# Altium schematic sheet Microcontroller.SchDoc
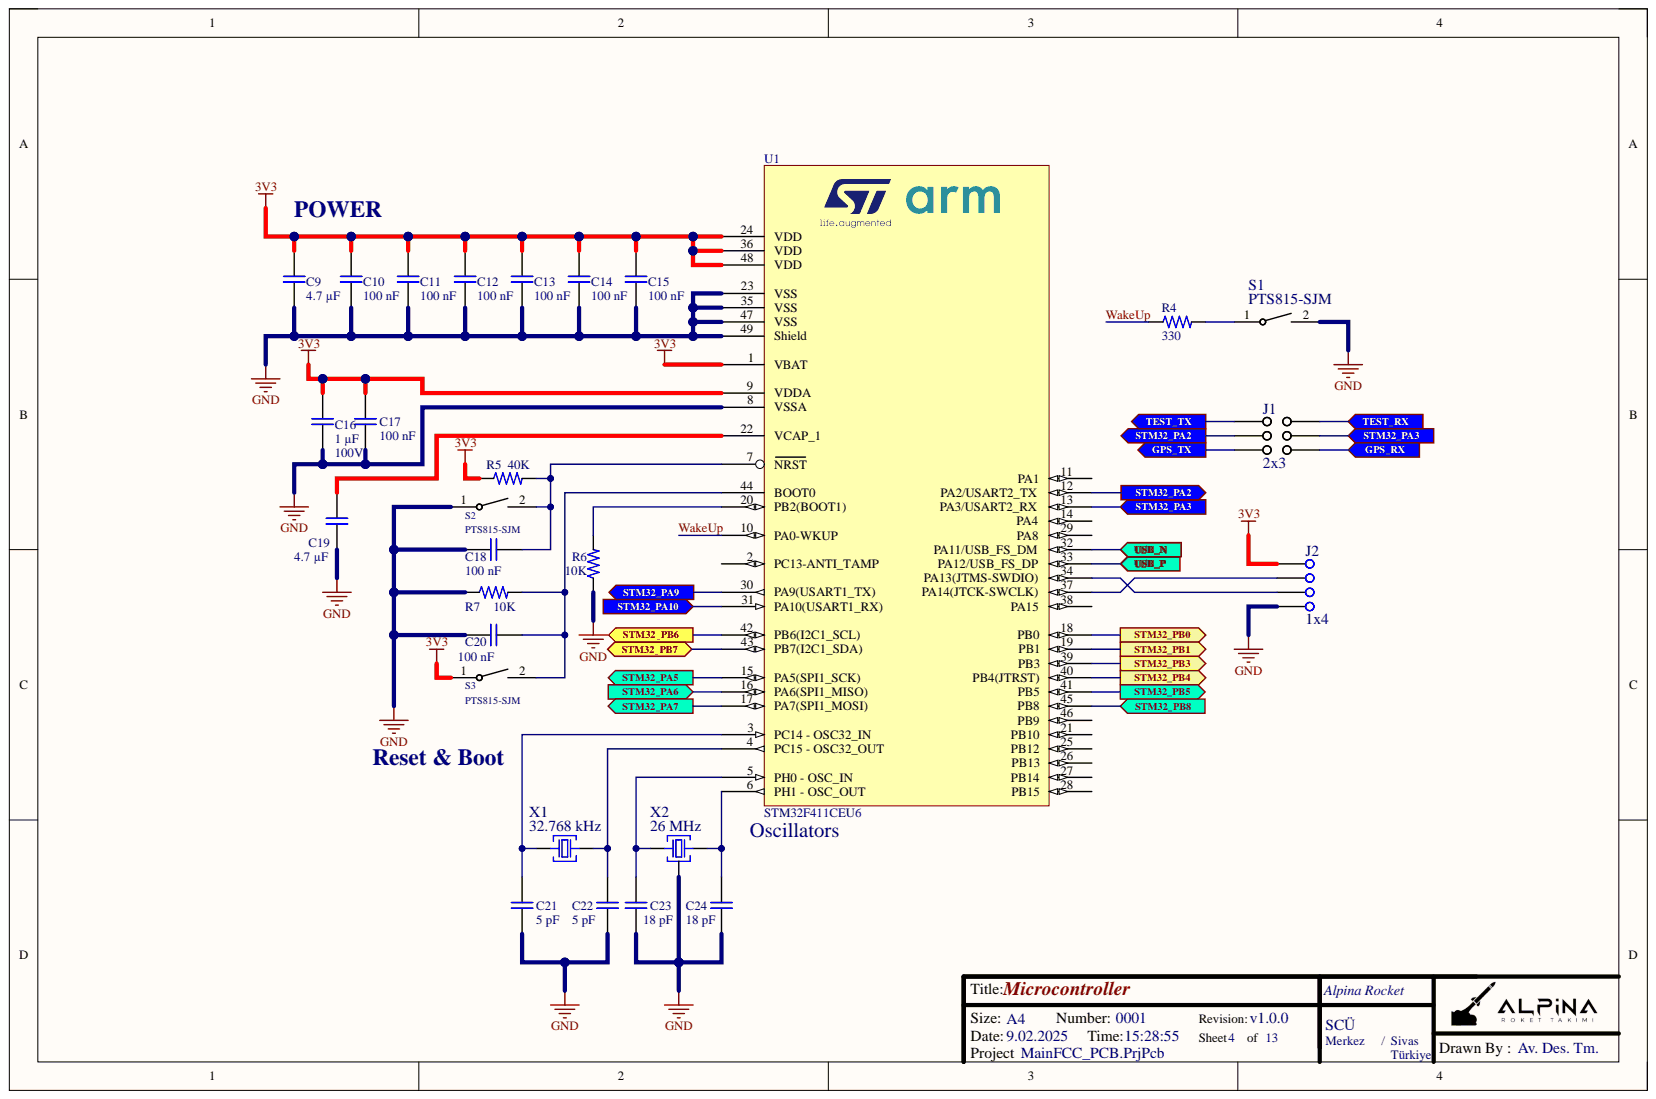
[diagram p0: Microcontroller.SchDoc]

## Parts
C9  4.7 µF  [MergenElektronik_Capacitors_Ceramics_SMDs_0603_M_NS]
C10  100 nF  [MergenElektronik_Capacitors_Ceramics_SMDs_0603_M_NS]
C11  100 nF  [MergenElektronik_Capacitors_Ceramics_SMDs_0603_M_NS]
C12  100 nF  [MergenElektronik_Capacitors_Ceramics_SMDs_0603_M_NS]
C13  100 nF  [MergenElektronik_Capacitors_Ceramics_SMDs_0603_M_NS]
C14  100 nF  [MergenElektronik_Capacitors_Ceramics_SMDs_0603_M_NS]
C15  100 nF  [MergenElektronik_Capacitors_Ceramics_SMDs_0603_M_NS]
C16  1 µF  Voltage Rating: 100V  [MergenElektronik_Capacitors_Ceramics_SMDs_1206_M_NS]
C17  100 nF  Voltage Rating: 100V  [MergenElektronik_Capacitors_Ceramics_SMDs_0603_M_NS]
C18  100 nF  [MergenElektronik_Capacitors_Ceramics_SMDs_0603_M_NS]
C19  4.7 µF  [MergenElektronik_Capacitors_Ceramics_SMDs_0603_M_NS]
C20  100 nF  [MergenElektronik_Capacitors_Ceramics_SMDs_0603_M_NS]
C21  5 pF  [MergenElektronik_Capacitors_Ceramics_SMDs_0603_M_NS]
C22  5 pF  [MergenElektronik_Capacitors_Ceramics_SMDs_0603_M_NS]
C23  18 pF  [MergenElektronik_Capacitors_Ceramics_SMDs_0603_M_NS]
C24  18 pF  [MergenElektronik_Capacitors_Ceramics_SMDs_0603_M_NS]
J1  2x3  [MergenElektronik_Connectors_Headers_2x3_Male]
J2  1x4  [MergenElektronik_Connectors_Headers_1x4_Male]
R4  330  [MergenElektronik_Resistors_ChipResistors_0603_M_NS]
R5  40K  [MergenElektronik_Resistors_ChipResistors_0603_M_NS]
R6  10K  [MergenElektronik_Resistors_ChipResistors_0603_M_NS]
R7  10K  [MergenElektronik_Resistors_ChipResistors_0603_M_NS]
S1  PTS815-SJM  [MergenElektronik_Switches_TactileSwitches_PTS815]
S2  PTS815-SJM  [MergenElektronik_Switches_TactileSwitches_PTS815]
S3  PTS815-SJM  [MergenElektronik_Switches_TactileSwitches_PTS815]
U1  STM32F411CEU6  [MergenElektronik_Microcontrollers_STMicroelectronics_UFQFPN-48]
X1  32.768 kHz  [MergenElektronik_Oscillators_Crystals_2-SMD]
X2  26 MHz  [MergenElektronik_Oscillators_Crystals_4-SMD]

## Ports
GPS_RX, GPS_TX, STM32_PA2, STM32_PA3, STM32_PA5, STM32_PA6, STM32_PA7, STM32_PA9, STM32_PA10, STM32_PB0, STM32_PB1, STM32_PB3, STM32_PB4, STM32_PB5, STM32_PB6, STM32_PB7, STM32_PB8, TEST_RX, TEST_TX, USB_N, USB_P

## Nets
3V3: C9.1 C10.1 C11.1 C12.1 C13.1 C14.1 C15.1 C16.1 C17.1 J2.4 R5.1 S3.1 U1.1(VBAT) U1.9(VDDA) U1.24(VDD) U1.36(VDD) U1.48(VDD)
GND: C9.2 C10.2 C11.2 C12.2 C13.2 C14.2 C15.2 C16.2 C17.2 C18.2 C19.2 C20.2 C21.2 C22.2 C23.2 C24.2 J2.1 R6.1 R7.1 S1.2 S2.1 U1.8(VSSA) U1.23(VSS) U1.35(VSS) U1.47(VSS) U1.49(Shield) X2.3
GPS_RX: J1.4 ; port GPS_RX
GPS_TX: J1.1 ; port GPS_TX
STM32_PA2: J1.2 U1.12(PA2/USART2_TX) ; port STM32_PA2
STM32_PA3: J1.5 U1.13(PA3/USART2_RX) ; port STM32_PA3
STM32_PA5: U1.15(PA5(SPI1_SCK)) ; port STM32_PA5
STM32_PA6: U1.16(PA6(SPI1_MISO)) ; port STM32_PA6
STM32_PA7: U1.17(PA7(SPI1_MOSI)) ; port STM32_PA7
STM32_PA9: U1.30(PA9(USART1_TX)) ; port STM32_PA9
STM32_PA10: U1.31(PA10(USART1_RX)) ; port STM32_PA10
STM32_PB0: U1.18(PB0) ; port STM32_PB0
STM32_PB1: U1.19(PB1) ; port STM32_PB1
STM32_PB3: U1.39(PB3) ; port STM32_PB3
STM32_PB4: U1.40(PB4(JTRST)) ; port STM32_PB4
STM32_PB5: U1.41(PB5) ; port STM32_PB5
STM32_PB6: U1.42(PB6(I2C1_SCL)) ; port STM32_PB6
STM32_PB7: U1.43(PB7(I2C1_SDA)) ; port STM32_PB7
STM32_PB8: U1.45(PB8) ; port STM32_PB8
TEST_RX: J1.6 ; port TEST_RX
TEST_TX: J1.3 ; port TEST_TX
USB_N: U1.32(PA11/USB_FS_DM) ; port USB_N
USB_P: U1.33(PA12/USB_FS_DP) ; port USB_P
WakeUp: R4.1 U1.10(PA0-WKUP)
NetC18_1: C18.1 R5.2 S2.2 U1.7(NRST)
NetC19_1: C19.1 U1.22(VCAP_1)
NetC20_1: C20.1 R7.2 S3.2 U1.44(BOOT0)
NetC21_1: C21.1 U1.3(PC14_-_OSC32_IN) X1.1
NetC22_1: C22.1 U1.4(PC15_-_OSC32_OUT) X1.2
NetC23_1: C23.1 U1.5(PH0_-_OSC_IN) X2.1
NetC24_1: C24.1 U1.6(PH1_-_OSC_OUT) X2.2
NetJ2_2: J2.2 U1.34(PA13(JTMS-SWDIO))
NetJ2_3: J2.3 U1.37(PA14(JTCK-SWCLK))
NetR4_2: R4.2 S1.1
NetR6_2: R6.2 U1.20(PB2(BOOT1))

Not connected: U1 2(PC13-ANTI_TAMP) 11(PA1) 14(PA4) 21(PB10) 25(PB12) 26(PB13) 27(PB14) 28(PB15) 29(PA8) 38(PA15) 46(PB9)

## Library data of the parts
C9 C19 = MergenElektronik_Capacitors_Ceramic_SMDs_4.7µF_16V: Mergen Elektronik - Capacitors - Ceramics - SMDs - 0603 - 4.7 µF - 16V; Manufacturer: Samsung Electro-Mechanics; Manufacturer Number: CL10A475KO8NNNC; Model: Ceramic Capacitors; Tolerance: ±10%; Component Kind: Standard; Digi-Key Number: 1276-1784-2-ND; Farnell Number: 3013397; Library Name: MergenElektronik_Capacitors_Ceramics_SMDs.SchLib; Mounting Type: Surface Mount; Mouser Number: 187-CL10A475KO8NNNC; Package: 0603(1608); Supplier 1: Digi-Key; Supplier 2: Mouser; Supplier Part Number 1: 1276-1784-2-ND; Supplier Part Number 2: 187-CL10A475KO8NNNC; Temperature: -55°C up to +85°C; Value: 4.7 µF; Voltage Rating: 16V; Özdisan Number: CL10A475KO8NNNC
C10-C15 C17 C18 C20 = MergenElektronik_Capacitors_Ceramic_SMDs_100nF_100V: Mergen Elektronik - Capacitors - Ceramics - SMDs - 0603 - 100 nF - 100V; Manufacturer: Samsung Electro-Mechanics; Manufacturer Number: CL10B104KC8NNNC; Model: Ceramic Capacitors; Tolerance: ±10%; Component Kind: Standard; Digi-Key Number: 1276-6807-1-ND; Farnell Number: 3013412; Library Name: MergenElektronik_Capacitors_Ceramics_SMDs.SchLib; Mounting Type: Surface Mount; Mouser Number: 187-CL10B104KC8NNNC; Package: 0603(1608 Metric); Supplier 1: Digikey; Supplier 2: Mouser; Supplier Part Number 1: 1276-6807-1-ND; Supplier Part Number 2: 187-CL10B104KC8NNNC; Temperature: -55°C up to +125°C; Value: 100 nF; Özdisan Number: CL10B104KC8NNNC
  C10-C15 C18 C20: Voltage Rating: 100V
C16 = MergenElektronik_Capacitors_Ceramic_SMDs_1µF_100V: Mergen Elektronik - Capacitors - Ceramics - SMDs - 1206 - 1 µF - 100V; Manufacturer: Samsung Electro-Mechanics; Manufacturer Number: CL31B105KCHNNNE; Model: Ceramic Capacitors; Tolerance: ±10%; Component Kind: Standard; Digi-Key Number: 1276-1838-1-ND; Library Name: MergenElektronik_Capacitors_Ceramics_SMDs.SchLib; Mounting Type: Surface Mount; Mouser Number: 187-CL31B105KCHNNNE; Package: 1206(3216 Metric); Supplier 1: Digikey; Supplier 2: Mouser; Supplier Part Number 1: 1276-1838-1-ND; Supplier Part Number 2: 187-CL31B105KCHNNNE; Temperature: -55°C up to +125°C; Value: 1 µF; Özdisan Number: CL31B105KCHNNNE
C21 C22 = MergenElektronik_Capacitors_Ceramic_SMDs_5pF_50V: Mergen Elektronik - Capacitors - Ceramics - SMDs - 0603 - 5 pF - 50V; Manufacturer: Samsung Electro-Mechanics; Manufacturer Number: CL10C050CB81PNC; Model: Ceramic Capacitors; Tolerance: ±0.25 pF; Component Kind: Standard; Digi-Key Number: 1276-7005-2-ND; Library Name: MergenElektronik_Capacitors_Ceramics_SMDs.SchLib; Mounting Type: Surface Mount; Mouser Number: 187-CL10C050CB81PNC; Package: 0603(1608); Supplier 1: Digi-Key; Supplier 2: Mouser; Supplier Part Number 1: 1276-7005-2-ND; Supplier Part Number 2: 187-CL10C050CB81PNC; Temperature: -55°C up to +125°C; Value: 5 pF; Voltage Rating: 50V; Özdisan Number: CL10C050CB81PNC
C23 C24 = MergenElektronik_Capacitors_Ceramic_SMDs_18pF_50V: Mergen Elektronik - Capacitors - Ceramics - SMDs - 0603 - 18 pF - 50V; Manufacturer: YAGEO; Manufacturer Number: CC0603JRNPO9BN180; Model: Ceramic Capacitors; Tolerance: ±5%; Component Kind: Standard; Digi-Key Number: 311-1061-1-ND; Farnell Number: 2408496; Library Name: MergenElektronik_Capacitors_Ceramics_SMDs.SchLib; Mounting Type: Surface Mount; Mouser Number: 603-CC603JRNPO9BN180; Package: 0603(1608); Supplier 1: Mouser; Supplier 2: Digi-Key; Supplier Part Number 1: 603-CC603JRNPO9BN180; Supplier Part Number 2: 311-1061-6-ND; Temperature: -55°C up to +125°C; Value: 18 pF; Voltage Rating: 50V
J1 = MergenElektronik_Connectors_Headers_2x3_Male: Mergen Elektronik - Connectors - Headers - 2x3 Male; Component Kind: Standard; Library Name: MergenElektronik_Connectors_Headers.IntLib
J2 = MergenElektronik_Connectors_Headers_1x4_Male: Mergen Elektronik - Connectors - Headers - 1x4 Male; Component Kind: Standard; Library Name: MergenElektronik_Connectors_Headers.IntLib
R4 = MergenElektronik_Resistors_ChipResistors_0603_330R: Mergen Elektronik - Resistors - Chip Resistors - 0603 - 330R; Manufacturer: Stackpole Electronics Inc; Manufacturer Number: RMCF0603JT330R; Power: 1/10W; Tolerance: ±5%; Value: Chip Resistor - 0603 - 330R; Component Kind: Standard; Digi-Key Number: RMCF0603JT330RCT-ND; Library Name: MergenElektronik_Resistors_ChipResistors.IntLib; Model: Chip Resistor; Mounting Type: Surface Mount; Package: 0603(1608); Supplier 1: Digi-Key; Supplier Part Number 1: RMCF0603JT330RCT-ND; Temperature: -55°C up to +155°C - X8R
R5 = MergenElektronik_Resistors_ChipResistors_0603_40K: Mergen Elektronik - Resistors - Chip Resistors - 0603 - 40K; Manufacturer: YAGEO; Manufacturer Number: RT0603BRD0740KL; Power: 1/10W; Tolerance: ±0.1%; Value: Chip Resistor - 0603 - 40K; Component Kind: Standard; Digi-Key Number: 13-RT0603BRD0740KLTR-ND; Library Name: MergenElektronik_Resistors_ChipResistors.IntLib; Model: Chip Resistor; Mounting Type: Surface Mount; Mouser Number: 603-RT0603BRD0740KL; Package: 0603(1608); Supplier 1: Digikey; Supplier 2: Mouser; Supplier Part Number 1: 13-RT0603BRD0740KLDKR-ND; Supplier Part Number 2: 603-RT0603BRD0740KL; Temperature: -55°C up to +155°C - X8R
R6 R7 = MergenElektronik_Resistors_ChipResistors_0603_10K: Mergen Elektronik - Resistors - Chip Resistors - 0603 - 10K; Manufacturer: Stackpole Electronics Inc; Manufacturer Number: RMCF0603JT10K0; Power: 1/10W; Tolerance: ±5%; Value: Chip Resistor - 0603 - 10K; Component Kind: Standard; Digi-Key Number: RMCF0603JT10K0CT-ND; Library Name: MergenElektronik_Resistors_ChipResistors.IntLib; Model: Chip Resistor; Mounting Type: Surface Mount; Package: 0603(1608); Supplier 1: Digi-Key; Supplier Part Number 1: RMCF0603JT10K0-ND; Temperature: -55°C up to +155°C - X8R
S1-S3 = MergenElektronik_Switches_TactileSwitches_PTS815-SJM: Mergen Elektronik - Switches - Tactile  Switches; Manufacturer: C&K; Manufacturer Number: PTS815 SJM 250 SMTR LFS; Current Rating: 50mA; Voltage Rating: 12VDC; Component Kind: Standard; Digi-Key Number: CKN12215-1-ND; Farnell Number: 3780619; Library Name: MergenElektronik_Switches_TactileSwitches.SchLib; Model: Tactile Switches; Mounting Type: Surface Mount; Mouser Number: 611-PTS815SJM250SMTR; Package: PTS815; Supplier 1: Mouser; Supplier 2: Digi-Key; Supplier Part Number 1: 611-PTS815SJM250SMTR; Supplier Part Number 2: CKN12215-6-ND; Temperature: -20°C up to +70°C; Value: PTS815-SJM
U1 = MergenElektronik_Microcontrollers_STMicroelectronics_STM32F411CEU6: Mergen Elektronik - Microcontrollers - STMicroelectronics - STM32F411CEU6; Core Size: 32-Bit; Memory Size: 512kB; Model: ARM® Cortex®-M4 Microcontroller; Number of I/O: 36; Operating Supply Voltage: 1.7V up to 3.6V; Ram Size: 128K x 8; Speed: 100MHz with PLL; Component Kind: Standard; Digi-Key Number: 497-14907-ND; Farnell Number: 3365489; Library Name: MergenElektronik_Microcontrollers_STMicroelectronics.SchLib; Manufacturer: STMicroelectronics; Manufacturer Number: STM32F411CEU6; Mounting Type: Surface Mount; Mouser Number: 511-STM32F411CEU6; Package: UFQFPN-48; Supplier 1: Digikey; Supplier 2: Mouser; Supplier Part Number 1: 497-14907-ND; Supplier Part Number 2: 511-STM32F411CEU6; Temperature: -40°C up to 85°C (TA); Value: STM32F411CEU6
X1 = MergenElektronik_Oscillators_Crystals_2_SMD_12MHz_32.768kHz: Mergen Elektronik - Oscilators - Crystals - 2-SMD - 32.768 kHz; Manufacturer: ECS Inc.; Manufacturer Number: ECS-.327-5-16-TR; Load Capacitance: 5 pF; Model: Crystal Oscilator; Component Kind: Standard; Digi-Key Number: XC2843TR-ND; Frequency: 32.768 kHz; Library Name: MergenElektronik_Oscillators_Crystals.IntLib; Mounting Type: Surface Mount; Package: 2-SMD; Supplier 1: Digi-Key; Supplier Part Number 1: XC2843TR-ND; Temperature: -40°C up to 85°C; Value: 32.768 kHz
X2 = MergenElektronik_Oscillators_Crystals_4_SMD_26MHz: Mergen Elektronik - Oscilators - Crystals - 4-SMD - 26 MHz; Manufacturer: TXC CORPORATION; Manufacturer Number: 7M-26.000MAAJ-T; Load Capacitance: 18 pF; Model: Crystal Oscilator; Component Kind: Standard; Digi-Key Number: 887-1133-2-ND; Farnell Number: 1842069; Frequency: 26 MHz; Library Name: MergenElektronik_Oscillators_Crystals.IntLib; Mounting Type: Surface Mount; Mouser Number: 717-7M-26.000MAAJ-T; Package: 4-SMD; Supplier 1: Digi-Key; Supplier 2: Mouser; Supplier Part Number 1: 2151-RH100-12.000-18-2030-TRCT-ND; Supplier Part Number 2: 717-7M-26.000MAAJ-T; Temperature: -20°C up to 70°C; Value: 26 MHz
